# Altium schematic sheet Schematic.SchDoc
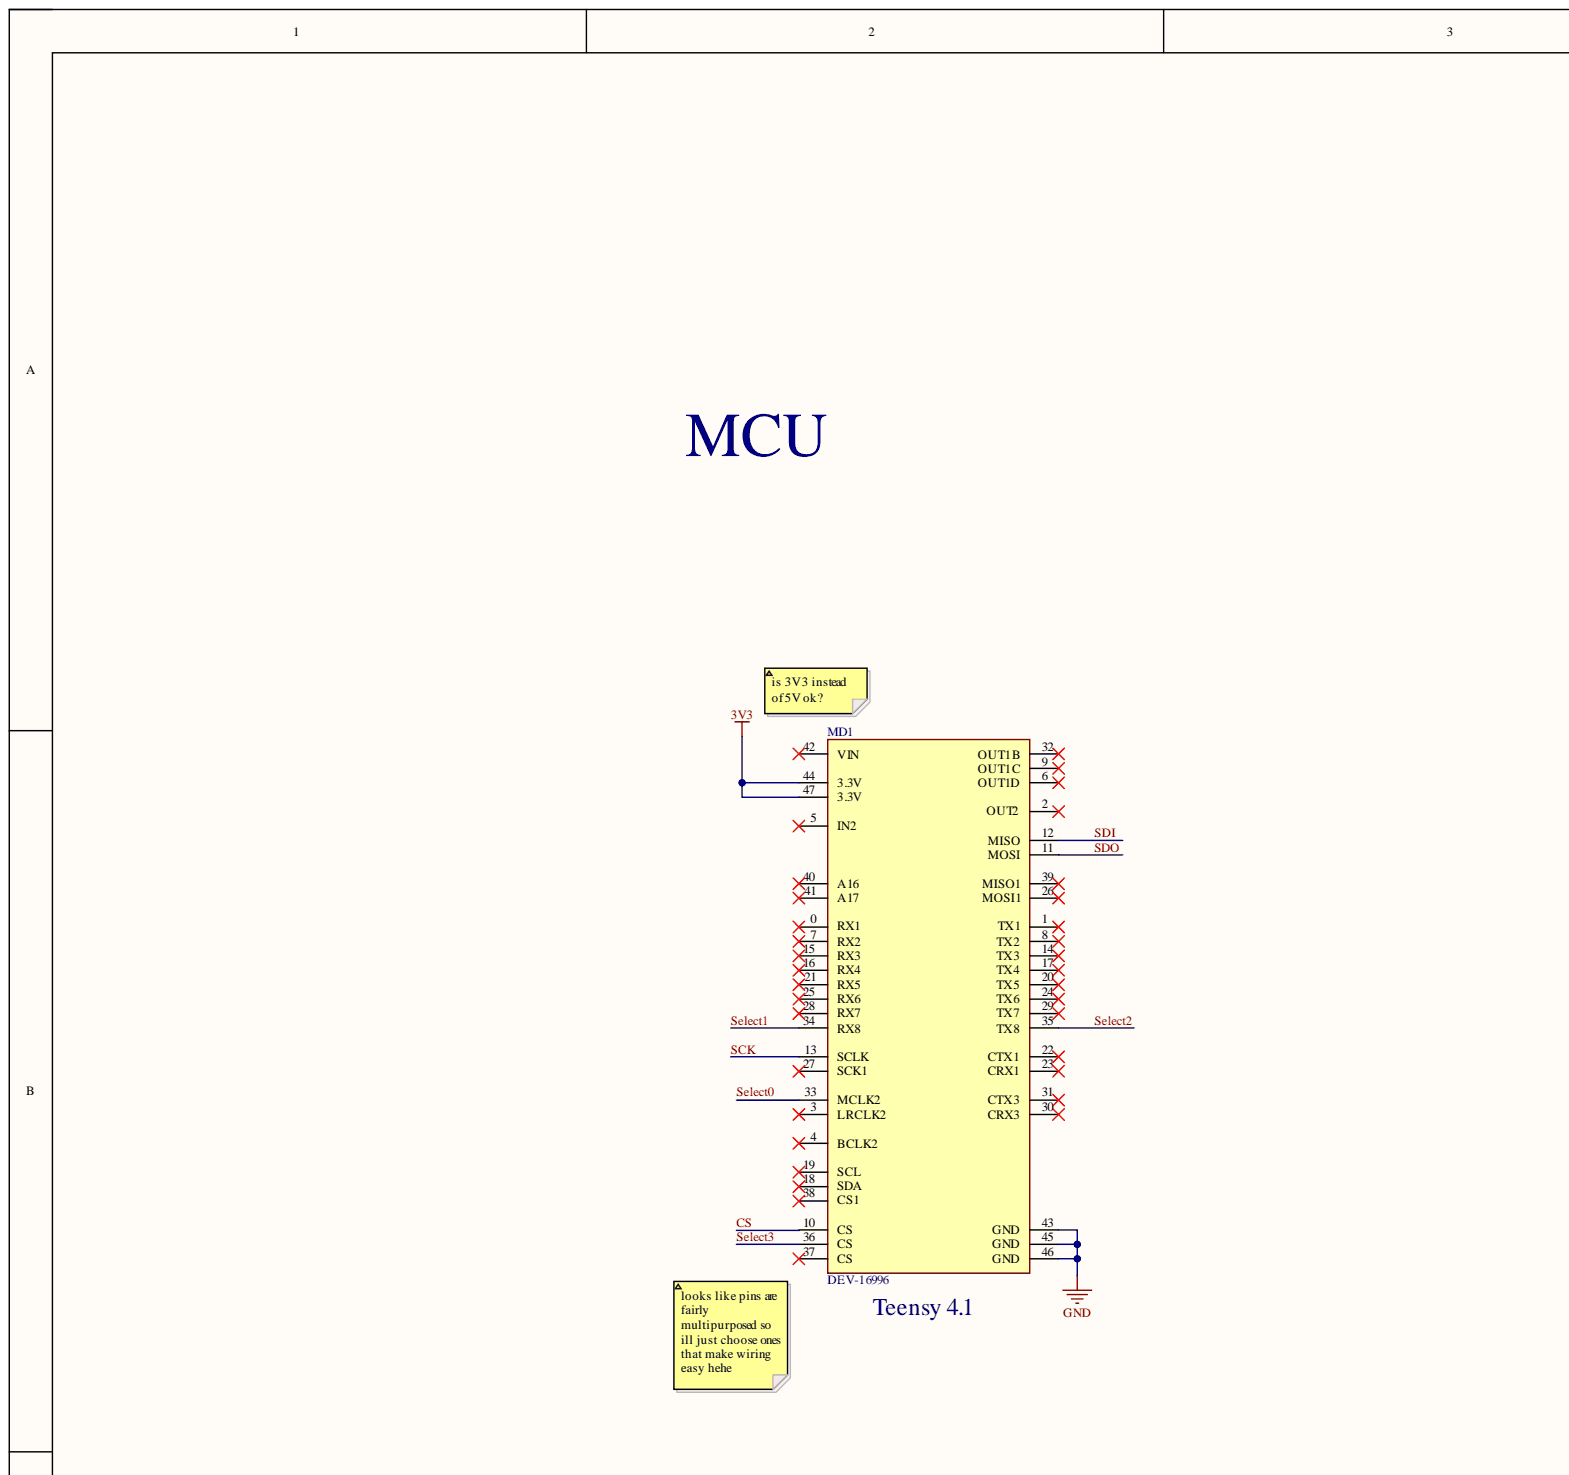
[diagram p0: Schematic.SchDoc, top left]
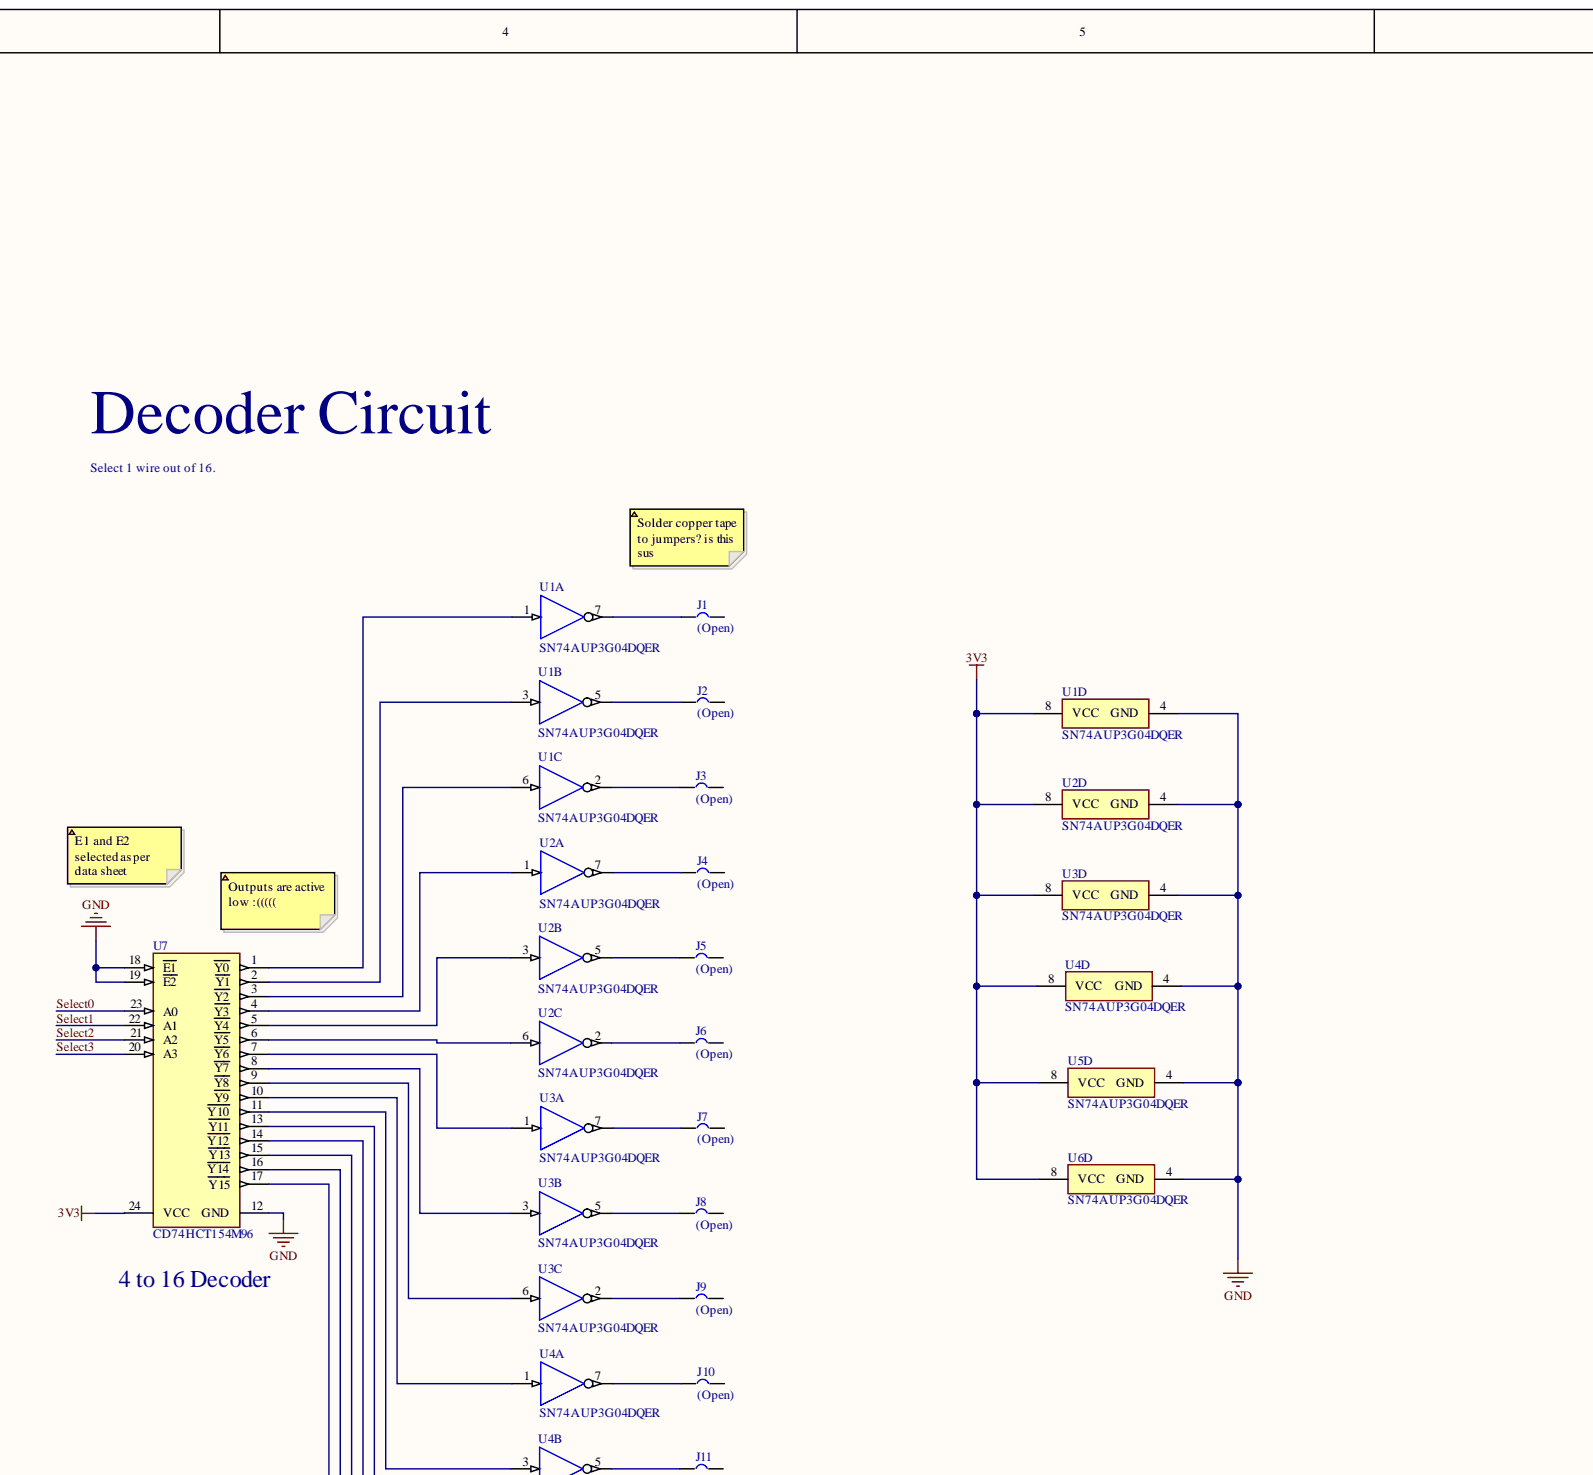
[diagram p1: Schematic.SchDoc, top centre]
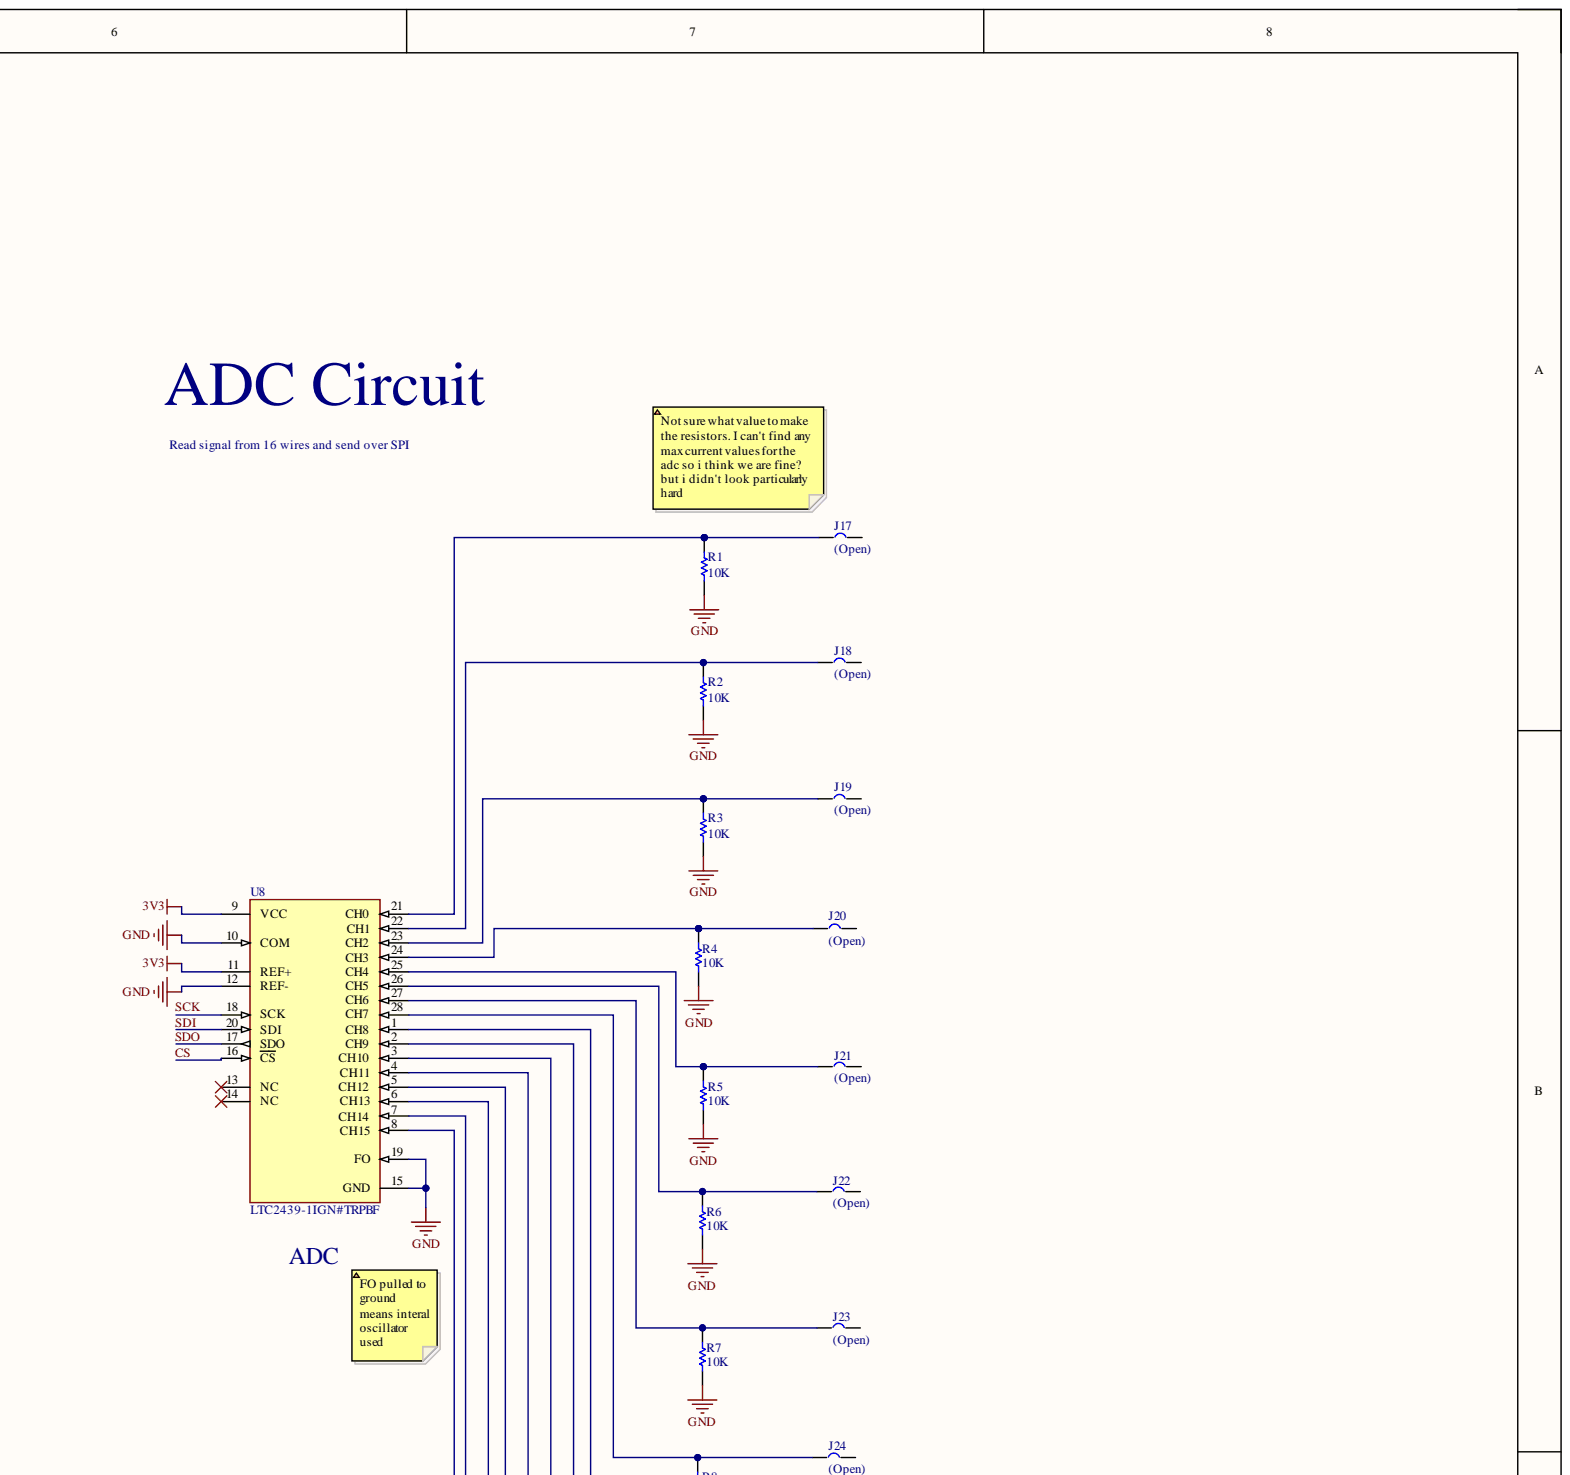
[diagram p2: Schematic.SchDoc, top right]
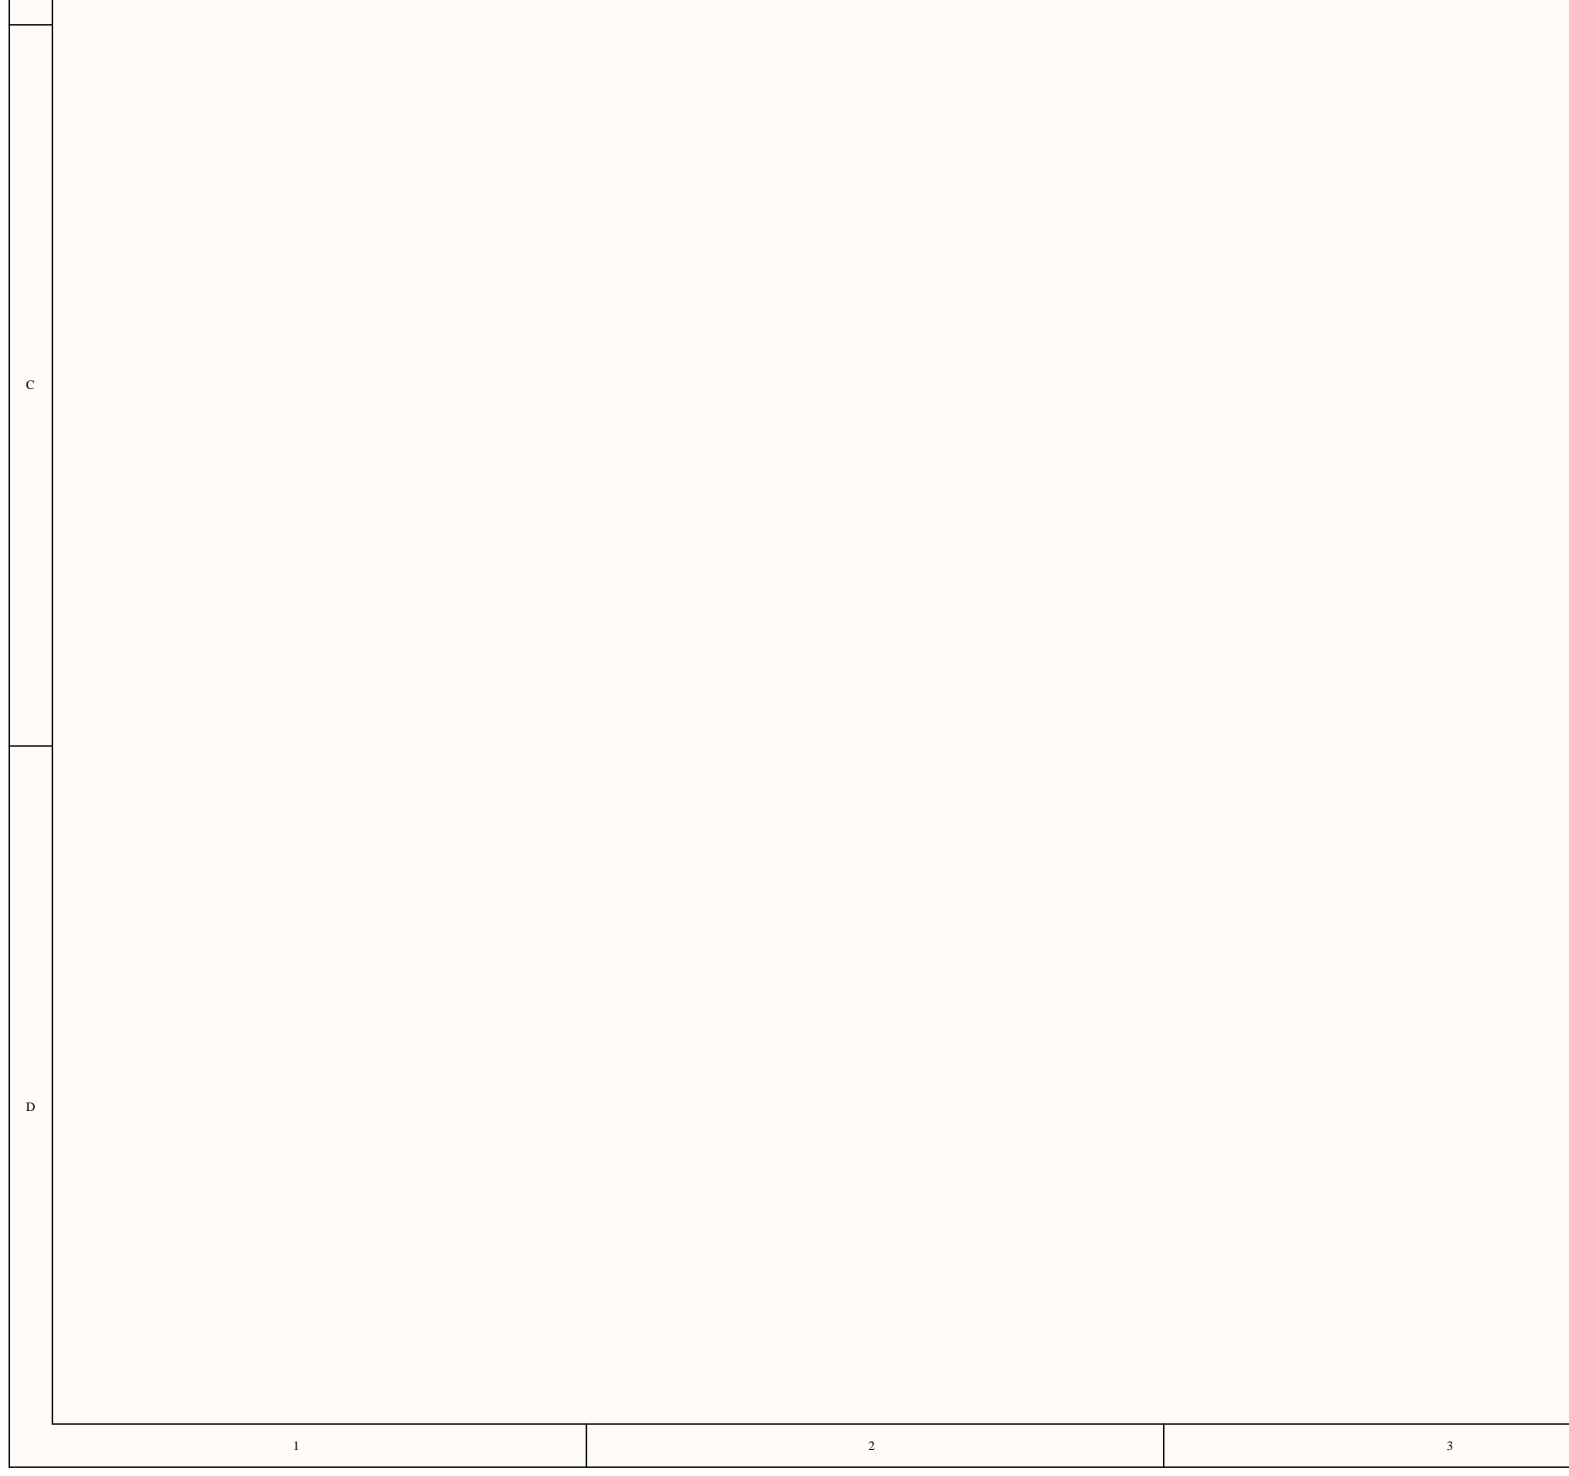
[diagram p3: Schematic.SchDoc, bottom left]
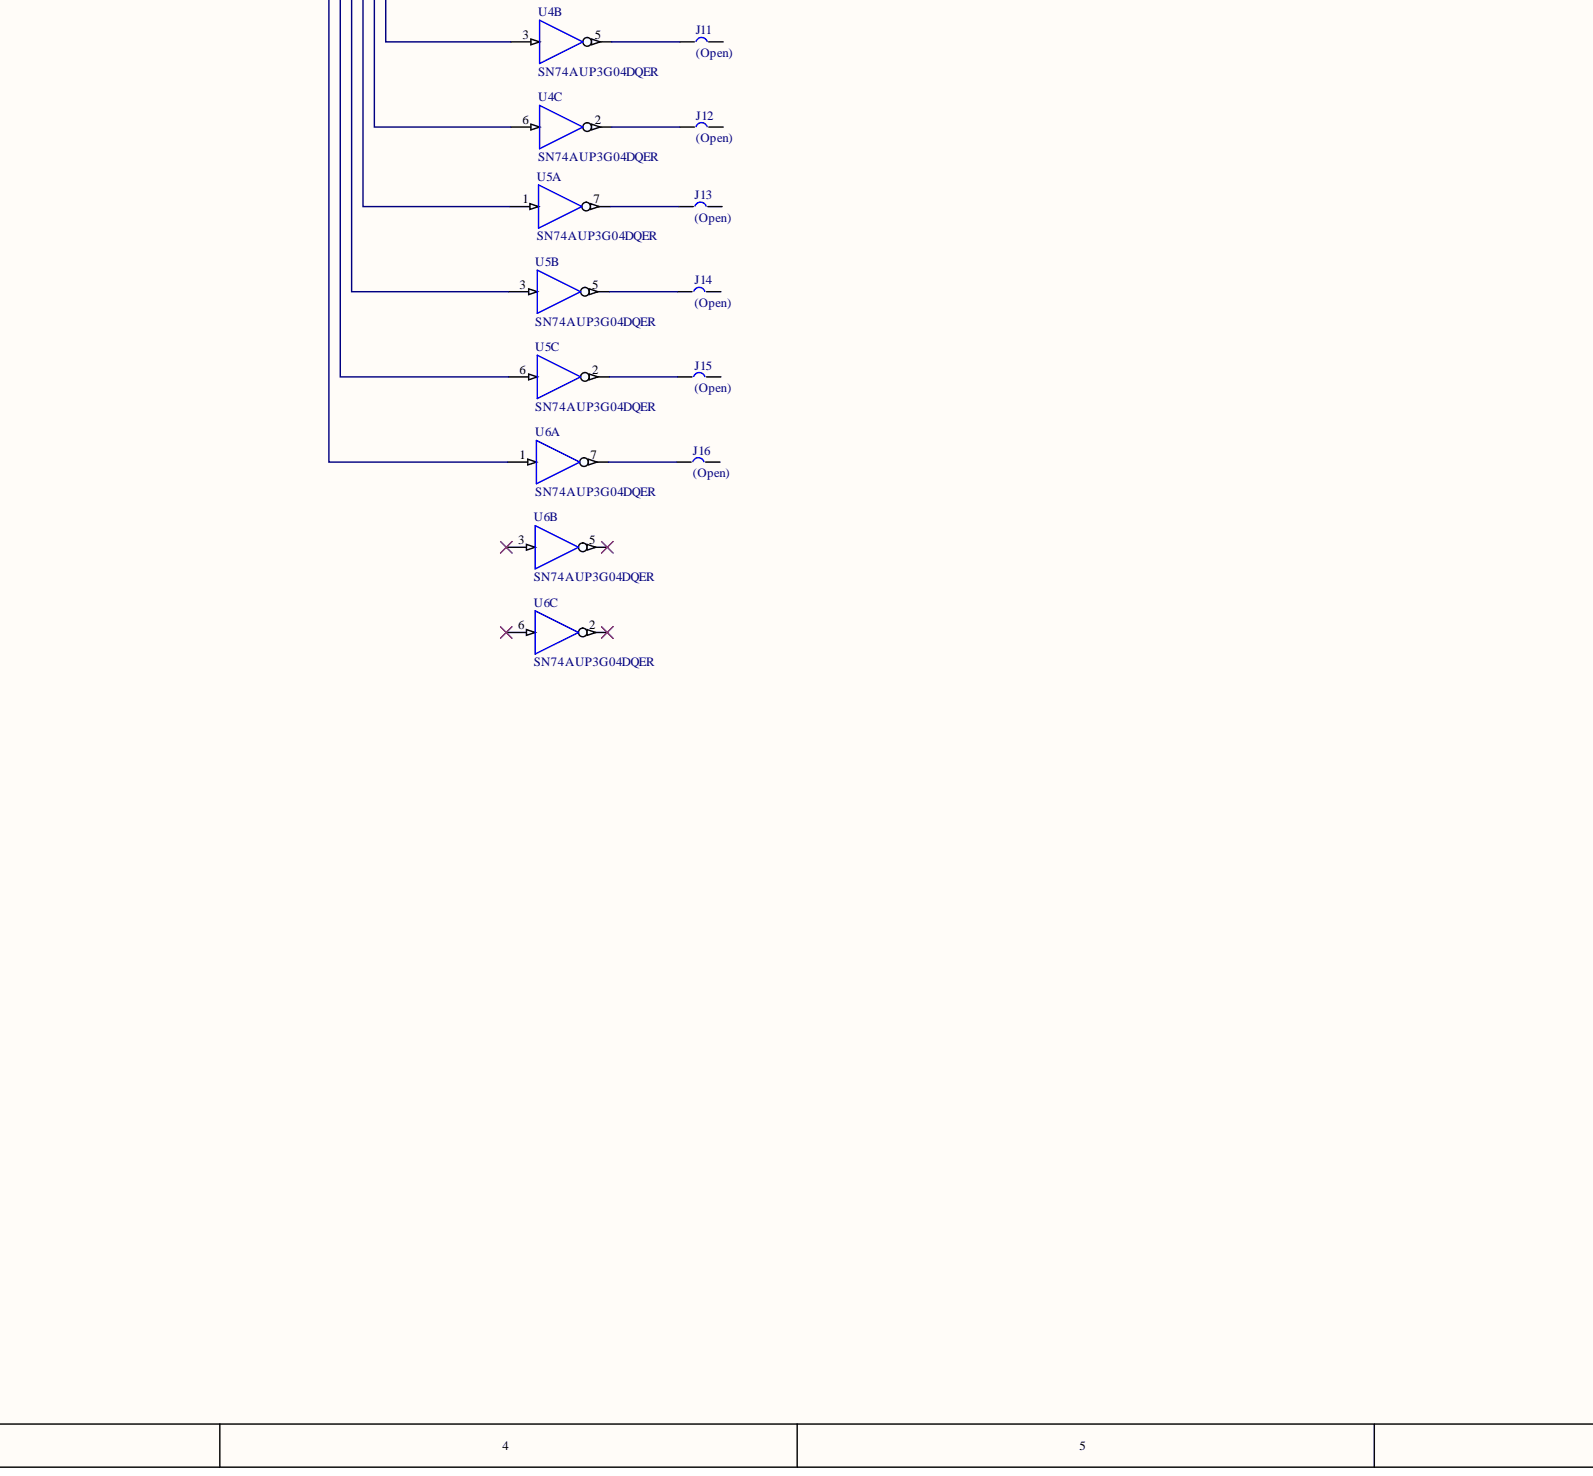
[diagram p4: Schematic.SchDoc, bottom centre]
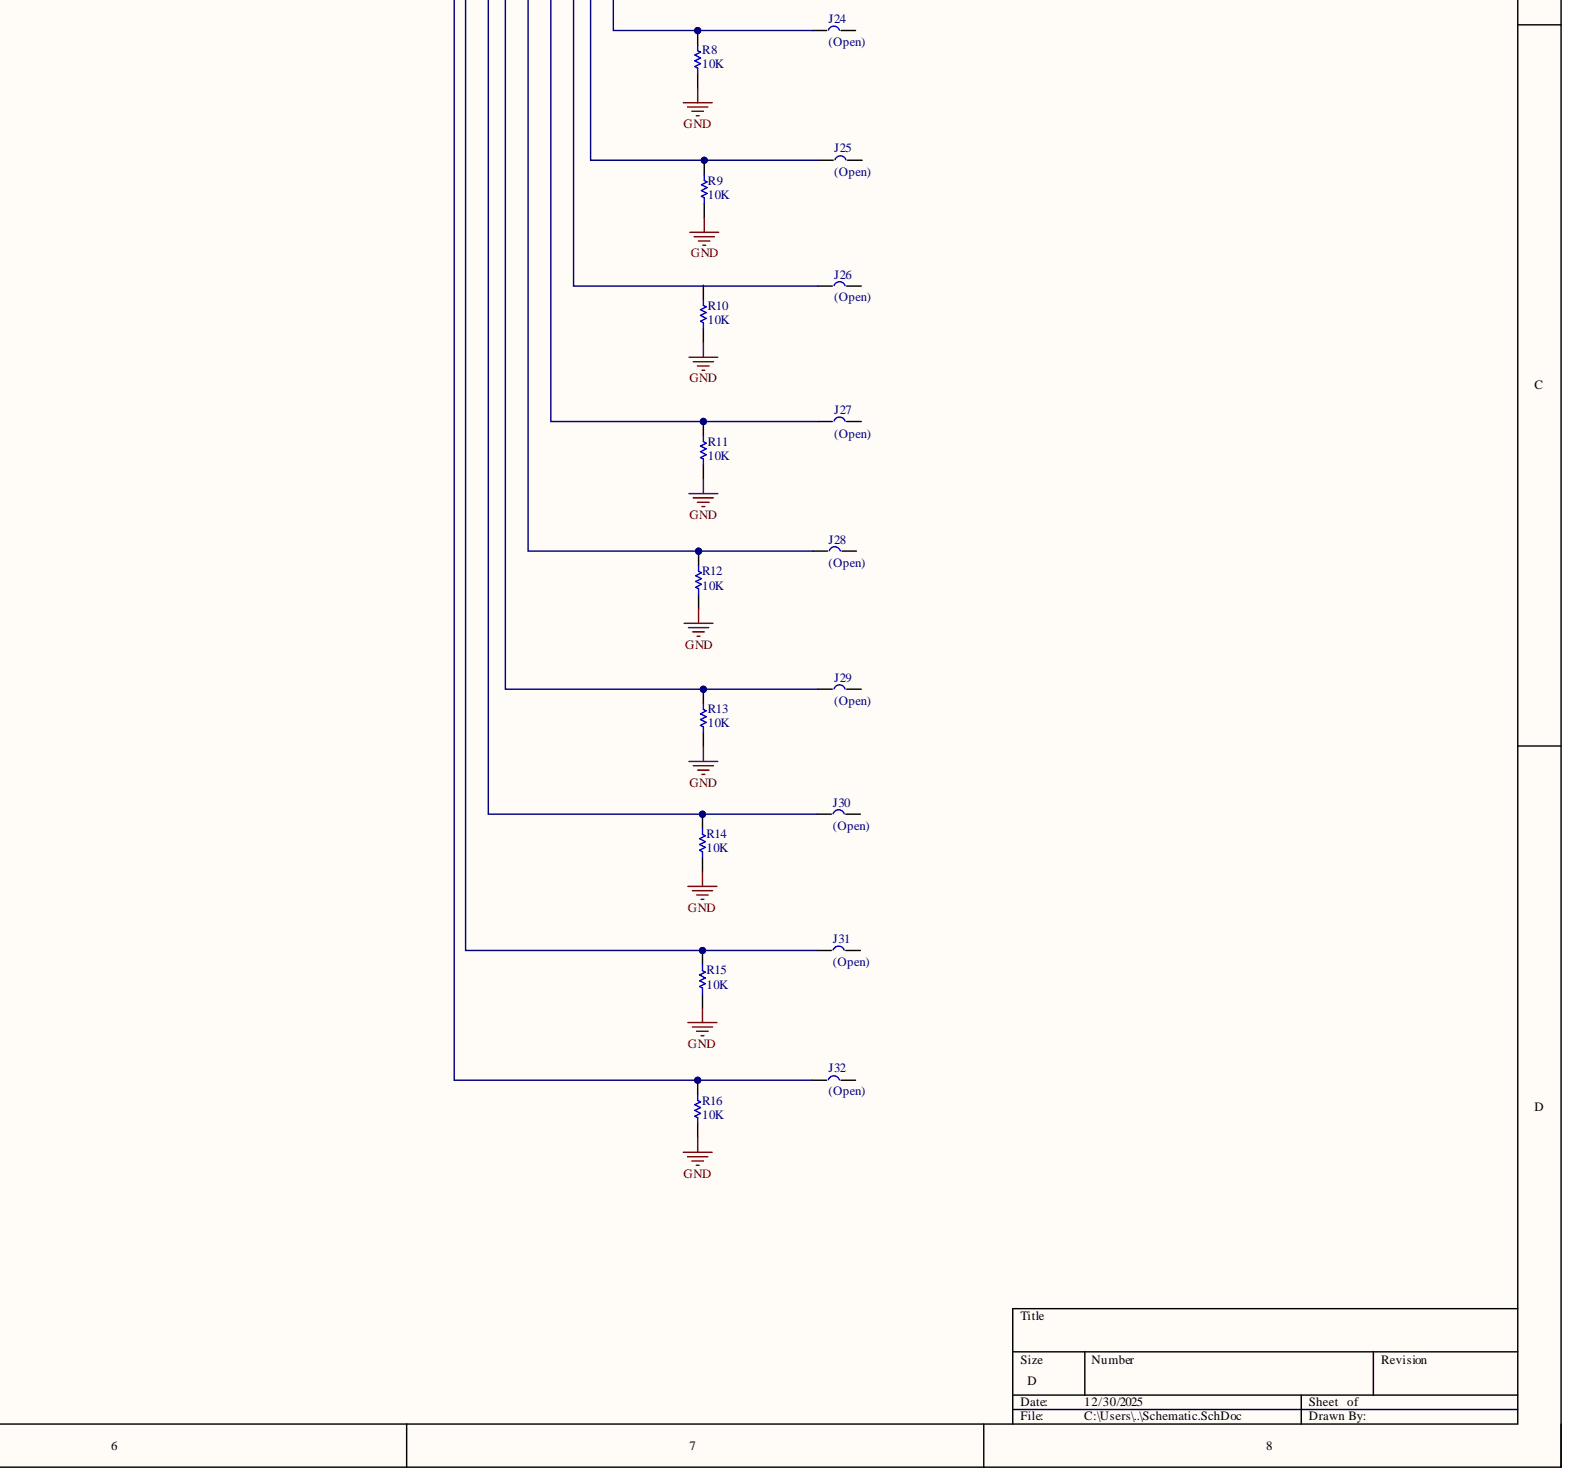
[diagram p5: Schematic.SchDoc, bottom right]

## Parts
J1  (Open)  [Solder Jumper]
J2  (Open)  [Solder Jumper]
J3  (Open)  [Solder Jumper]
J4  (Open)  [Solder Jumper]
J5  (Open)  [Solder Jumper]
J6  (Open)  [Solder Jumper]
J7  (Open)  [Solder Jumper]
J8  (Open)  [Solder Jumper]
J9  (Open)  [Solder Jumper]
J10  (Open)  [Solder Jumper]
J11  (Open)  [Solder Jumper]
J12  (Open)  [Solder Jumper]
J13  (Open)  [Solder Jumper]
J14  (Open)  [Solder Jumper]
J15  (Open)  [Solder Jumper]
J16  (Open)  [Solder Jumper]
J17  (Open)  [Solder Jumper]
J18  (Open)  [Solder Jumper]
J19  (Open)  [Solder Jumper]
J20  (Open)  [Solder Jumper]
J21  (Open)  [Solder Jumper]
J22  (Open)  [Solder Jumper]
J23  (Open)  [Solder Jumper]
J24  (Open)  [Solder Jumper]
J25  (Open)  [Solder Jumper]
J26  (Open)  [Solder Jumper]
J27  (Open)  [Solder Jumper]
J28  (Open)  [Solder Jumper]
J29  (Open)  [Solder Jumper]
J30  (Open)  [Solder Jumper]
J31  (Open)  [Solder Jumper]
J32  (Open)  [Solder Jumper]
MD1  DEV-16996  [FP-DEV-16996-MFG]
R1  10K  [RES_SM0603_YAGEORC_N]
R2  10K  [RES_SM0603_YAGEORC_N]
R3  10K  [RES_SM0603_YAGEORC_N]
R4  10K  [RES_SM0603_YAGEORC_N]
R5  10K  [RES_SM0603_YAGEORC_N]
R6  10K  [RES_SM0603_YAGEORC_N]
R7  10K  [RES_SM0603_YAGEORC_N]
R8  10K  [RES_SM0603_YAGEORC_N]
R9  10K  [RES_SM0603_YAGEORC_N]
R10  10K  [RES_SM0603_YAGEORC_N]
R11  10K  [RES_SM0603_YAGEORC_N]
R12  10K  [RES_SM0603_YAGEORC_N]
R13  10K  [RES_SM0603_YAGEORC_N]
R14  10K  [RES_SM0603_YAGEORC_N]
R15  10K  [RES_SM0603_YAGEORC_N]
R16  10K  [RES_SM0603_YAGEORC_N]
U1  SN74AUP3G04DQER  [DQE0008A_V]  (parts A,B,C,D)
U2  SN74AUP3G04DQER  [DQE0008A_V]  (parts A,B,C,D)
U3  SN74AUP3G04DQER  [DQE0008A_V]  (parts A,B,C,D)
U4  SN74AUP3G04DQER  [DQE0008A_V]  (parts A,B,C,D)
U5  SN74AUP3G04DQER  [DQE0008A_V]  (parts A,B,C,D)
U6  SN74AUP3G04DQER  [DQE0008A_V]  (parts A,B,C,D)
U7  CD74HCT154M96  [DW0024A_L]
U8  LTC2439-1IGN#TRPBF  [FP-GN-28-05-08-1641-IPC_A]

## Nets
3V3: MD1.44(3.3V) MD1.47(3.3V) U1.8(VCC) U2.8(VCC) U3.8(VCC) U4.8(VCC) U5.8(VCC) U6.8(VCC) U7.24(VCC) U8.9(VCC) U8.11(REF+)
GND: MD1.43(GND) MD1.45(GND) MD1.46(GND) R1.1 R2.1 R3.1 R4.1 R5.1 R6.1 R7.1 R8.1 R9.1 R10.1 R11.1 R12.1 R13.1 R14.1 R15.1 R16.1 U1.4(GND) U2.4(GND) U3.4(GND) U4.4(GND) U5.4(GND) U6.4(GND) U7.12(GND) U7.18(E1) U7.19(E2) U8.10(COM) U8.12(REF-) U8.15(GND) U8.19(FO)
CS: MD1.10(CS) U8.16(CS)
SCK: MD1.13(SCLK) U8.18(SCK)
SDI: MD1.12(MISO) U8.20(SDI)
SDO: MD1.11(MOSI) U8.17(SDO)
Select0: MD1.33(MCLK2) U7.23(A0)
Select1: MD1.34(RX8) U7.22(A1)
Select2: MD1.35(TX8) U7.21(A2)
Select3: MD1.36(CS) U7.20(A3)
NetJ1_1: J1.1 U1.7
NetJ2_1: J2.1 U1.5
NetJ3_1: J3.1 U1.2
NetJ4_1: J4.1 U2.7
NetJ5_1: J5.1 U2.5
NetJ6_1: J6.1 U2.2
NetJ7_1: J7.1 U3.7
NetJ8_1: J8.1 U3.5
NetJ9_1: J9.1 U3.2
NetJ10_1: J10.1 U4.7
NetJ11_1: J11.1 U4.5
NetJ12_1: J12.1 U4.2
NetJ13_1: J13.1 U5.7
NetJ14_1: J14.1 U5.5
NetJ15_1: J15.1 U5.2
NetJ16_1: J16.1 U6.7
NetJ17_1: J17.1 R1.2 U8.21(CH0)
NetJ18_1: J18.1 R2.2 U8.22(CH1)
NetJ19_1: J19.1 R3.2 U8.23(CH2)
NetJ20_1: J20.1 R4.2 U8.24(CH3)
NetJ21_1: J21.1 R5.2 U8.25(CH4)
NetJ22_1: J22.1 R6.2 U8.26(CH5)
NetJ23_1: J23.1 R7.2 U8.27(CH6)
NetJ24_1: J24.1 R8.2 U8.28(CH7)
NetJ25_1: J25.1 R9.2 U8.1(CH8)
NetJ26_1: J26.1 U8.2(CH9)
NetJ27_1: J27.1 R11.2 U8.3(CH10)
NetJ28_1: J28.1 R12.2 U8.4(CH11)
NetJ29_1: J29.1 R13.2 U8.5(CH12)
NetJ30_1: J30.1 R14.2 U8.6(CH13)
NetJ31_1: J31.1 R15.2 U8.7(CH14)
NetJ32_1: J32.1 R16.2 U8.8(CH15)
NetU1_1: U1.1 U7.1(Y0)
NetU1_3: U1.3 U7.2(Y1)
NetU1_6: U1.6 U7.3(Y2)
NetU2_1: U2.1 U7.4(Y3)
NetU2_3: U2.3 U7.5(Y4)
NetU2_6: U2.6 U7.6(Y5)
NetU3_1: U3.1 U7.7(Y6)
NetU3_3: U3.3 U7.8(Y7)
NetU3_6: U3.6 U7.9(Y8)
NetU4_1: U4.1 U7.10(Y9)
NetU4_3: U4.3 U7.11(Y10)
NetU4_6: U4.6 U7.13(Y11)
NetU5_1: U5.1 U7.14(Y12)
NetU5_3: U5.3 U7.15(Y13)
NetU5_6: U5.6 U7.16(Y14)
NetU6_1: U6.1 U7.17(Y15)

Not connected: J1 2; J2 2; J3 2; J4 2; J5 2; J6 2; J7 2; J8 2; J9 2; J10 2; J11 2; J12 2; J13 2; J14 2; J15 2; J16 2; J17 2; J18 2; J19 2; J20 2; J21 2; J22 2; J23 2; J24 2; J25 2; J26 2; J27 2; J28 2; J29 2; J30 2; J31 2; J32 2; MD1 0(RX1) 1(TX1) 2(OUT2) 3(LRCLK2) 4(BCLK2) 5(IN2) 6(OUT1D) 7(RX2) 8(TX2) 9(OUT1C) 14(TX3) 15(RX3) 16(RX4) 17(TX4) 18(SDA) 19(SCL) 20(TX5) 21(RX5) 22(CTX1) 23(CRX1) 24(TX6) 25(RX6) 26(MOSI1) 27(SCK1) 28(RX7) 29(TX7) 30(CRX3) 31(CTX3) 32(OUT1B) 37(CS) 38(CS1) 39(MISO1) 40(A16) 41(A17) 42(VIN); R10 2; U6 2 3 5 6; U8 13(NC) 14(NC)

## Library data of the parts
J1-J32 = Jumper: Component Kind: Standard; Description: Jumper; Library Name: Misc.IntLib
MD1 = CMP-157412-000001-1: TEENSY 4.1 (HEADERS); Component Kind: Standard; Library Name: Altium Content Vault
R1-R16 = RC0603FR-0710KL: RES 10K SM0603 YAGEO RC_L; Power (Watts): 0.1; Resistance (Ohms): 10000; Tolerance (%): 1; Category: Resistor; Component Kind: Standard; ComponentLink1Description: Manufacturer Website; ComponentLink1URL: http://www.yageo.com; Library Name: ResistorCapsInductors.IntLib; Manufacturer: Yageo; Manufacturer Part Number: RC0603FR-0710KL; Package: 0603; Supplier 1: Farnell; Supplier 2: Digi-Key; Supplier Part Number 1: 9238603; Supplier Part Number 2: 311-10.0KHRCT-ND; Value: 10K
U1-U6 = CMP-0859-00744-3: Low-Power Triple Inverter Gate, DQE0008A, LARGE T&R; Manufacturer: Texas Instruments; 3-State Output: No; Bits(#): 3; F @ Nom Voltage(Max)(Mhz): 100; ICC @ Nom Voltage(Max)(mA): 0.0009; Input Type: CMOS; Logic: Inverting; Output Drive (IOL/IOH)(Max)(mA): 20/-20; Rating: Catalog; Sub-Family: Inverting Buffer/Driver; Technology Family: AUP; tpd @ Nom Voltage(Max)(ns): 32.8,20.9,14.2,11,7.6,6.2; VCC(Max)(V): 3.6; VCC(Min)(V): 0.8; Voltage(Nom)(V): 0.8,1.2,1.5,1.8,2.5,3.3; Component Kind: Standard; DatasheetVersion: SCES762C; Generic Part Number: SN74AUP3G04; Library Name: Altium Content Vault; Operating Temperature Range(C): -40 to 85; Output Type: CMOS; PackageReference: DQE0008A; Schmitt Trigger: No
U7 = CMP-1633-00341-2: High Speed CMOS Logic 4-to-16 Line Decoder/Demultiplexer, DW0024A, LARGE T&R; Manufacturer: Texas Instruments; Bits(#): 16; Channels(#): 1; Configuration: 4：16; F @ Nom Voltage(Max)(Mhz): 25; ICC @ Nom Voltage(Max)(mA): 0.08; Input Type: TTL; Output Drive (IOL/IOH)(Max)(mA): 4/-4; Rating: Catalog; Schmitt Trigger: No; Technology Family: HCT; tpd @ Nom Voltage(Max)(ns): 44; VCC(Max)(V): 5.5; VCC(Min)(V): 4.5; Voltage(Nom)(V): 5; Code_JEDEC: MS-013; Component Kind: Standard; DatasheetVersion: SCHS152D; Function: Decoder/Demultiplexer; Generic Part Number: CD74HCT154; Library Name: Altium Content Vault; Operating Temperature Range(C): -55 to 125; Output Type: CMOS; PackageReference: DW0024A; Type: Standard
U8 = CMP-09081-000533-1: IC ADC 16BIT SIGMA-DELTA 28SSOP; Interface: SPI; Max Power Dissipation: 1mW; Number of Bits: 16; Number of Converters: 1; Sampling Rate: 6.8S/s; Supply Type: Single; Case/Package: SSOP; Component Kind: Standard; Lead Free: Lead Free; Library Name: Altium Content Vault; Max Operating Temperature: 85°C; Min Operating Temperature: -40°C; Mount: Surface Mount; Package Quantity: 2500; Packaging: Tape and Reel; RoHS Compliant: Yes
